# Altium schematic sheet Power.SchDoc
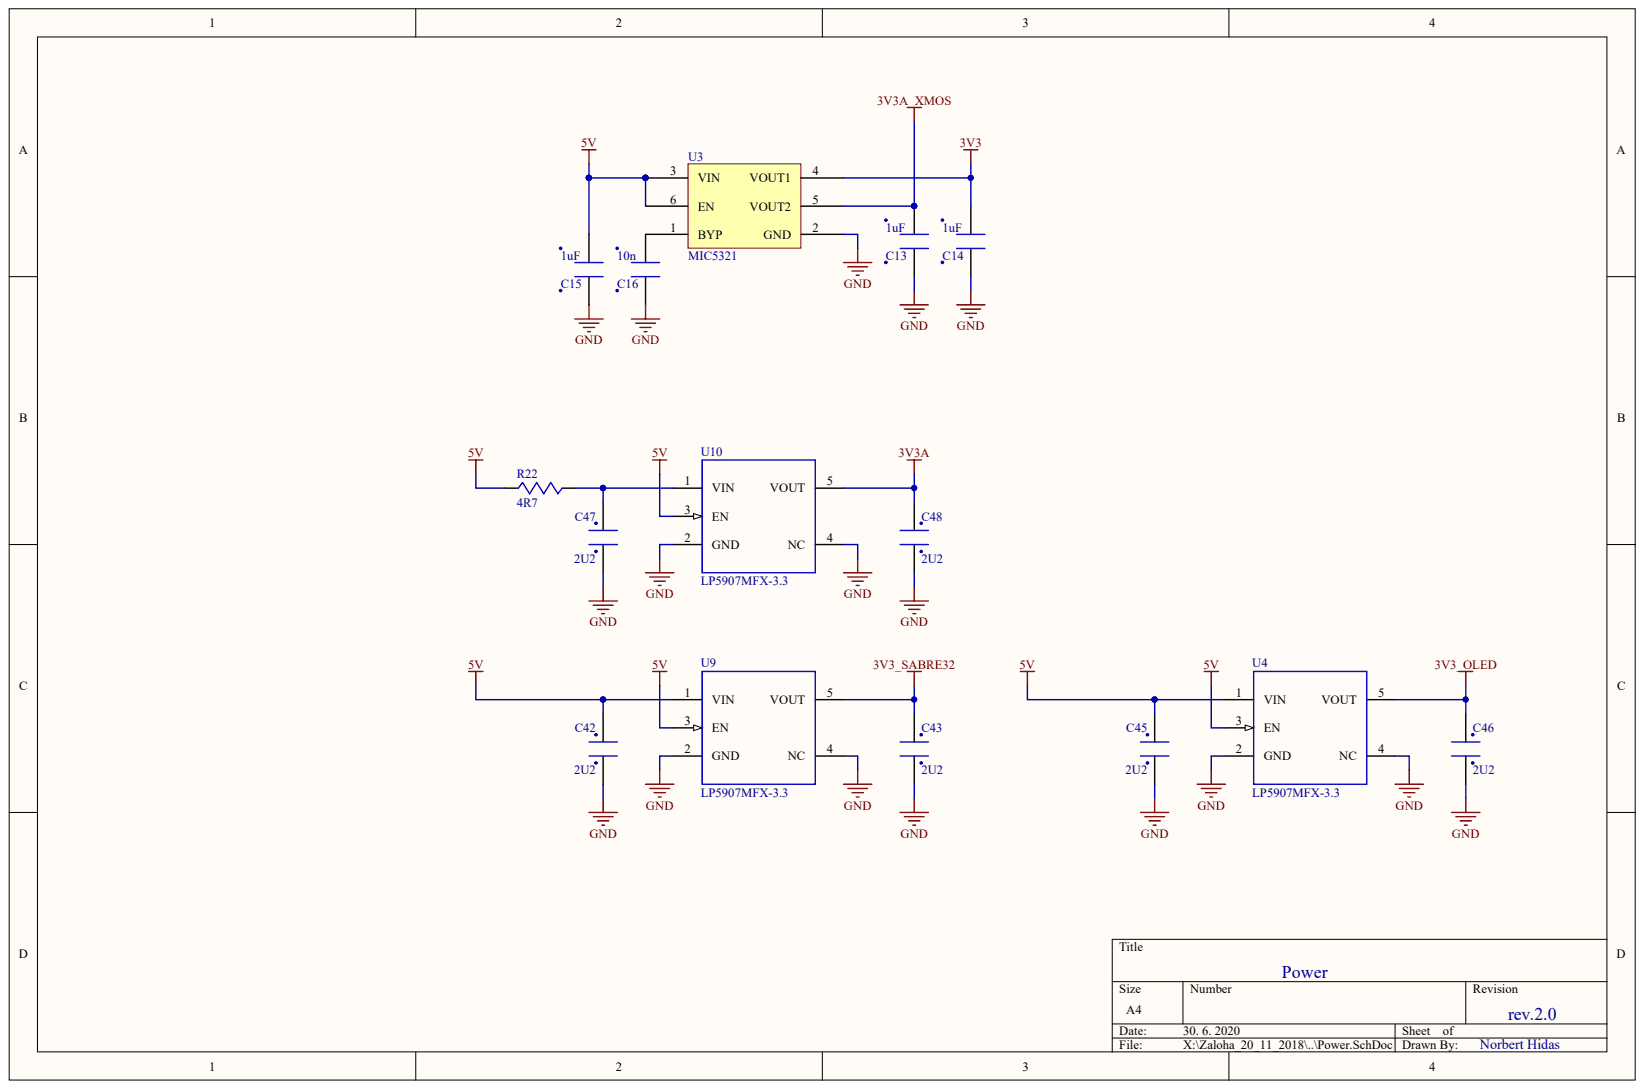
[diagram p0: Power.SchDoc]

## Parts
C13  1uF
C14  1uF
C15  1uF
C16  10n
C42  2U2
C43  2U2
C45  2U2
C46  2U2
C47  2U2
C48  2U2
R22  4R7
U3  MIC5321
U4  LP5907MFX-3.3
U9  LP5907MFX-3.3
U10  LP5907MFX-3.3

## Nets
3V3: C14.2 U3.4(VOUT1)
3V3_OLED: C46.1 U4.5(VOUT)
3V3_SABRE32: C43.1 U9.5(VOUT)
3V3A: C48.1 U10.5(VOUT)
3V3A_XMOS: C13.2 U3.5(VOUT2)
5V: C15.2 C42.1 C45.1 R22.1 U3.3(VIN) U3.6(EN) U4.1(VIN) U4.3(EN) U9.1(VIN) U9.3(EN) U10.3(EN)
GND: C13.1 C14.1 C15.1 C16.1 C42.2 C43.2 C45.2 C46.2 C47.2 C48.2 U3.2(GND) U4.2(GND) U4.4(NC) U9.2(GND) U9.4(NC) U10.2(GND) U10.4(NC)
NetC16_2: C16.2 U3.1(BYP)
NetC47_1: C47.1 R22.2 U10.1(VIN)

## Library data of the parts
C13-C16 = (no symbol name): Part Number: STD-06-0077; Manufacturer: Murata; Manufacturer Part Number: GRM188R61A225KE34D; VALUE: 2U2; Dielectric: X5R; Rated Voltage: 10V; Tolerance: 10%; Approx Volume Cost ($): 0.024
C42 C43 C45-C48 = (no symbol name): Part Number: STD-06-0077; Manufacturer: Murata; Manufacturer Part Number: GRM188R61A225KE34D; Dielectric: X5R; Rated Voltage: 10V; Tolerance: 10%; Approx Volume Cost ($): 0.024; VALUE: 2U2
R22 = (no symbol name): Part Number: STD-02-0010; Manufacturer: Yageo; Manufacturer Part Number: RC0603JR-074R7L; Tolerance: 5%; Max Voltage: 50V; Max Power: 100mW; Temperature Coefficient: ±200ppm/°C; Approx Volume Cost ($): 0.002; VALUE: 4R7
U4 U9 U10 = (no symbol name): Part Number: GEN-14-0011; Manufacturer: Texas Instruments; Approx Volume Cost ($): 0.2; VALUE: LP5907MFX-3.3; Manufacturer Part Number: LP5907MFX-3.3
